# Altium schematic sheet Circuit_Schematic.SchDoc
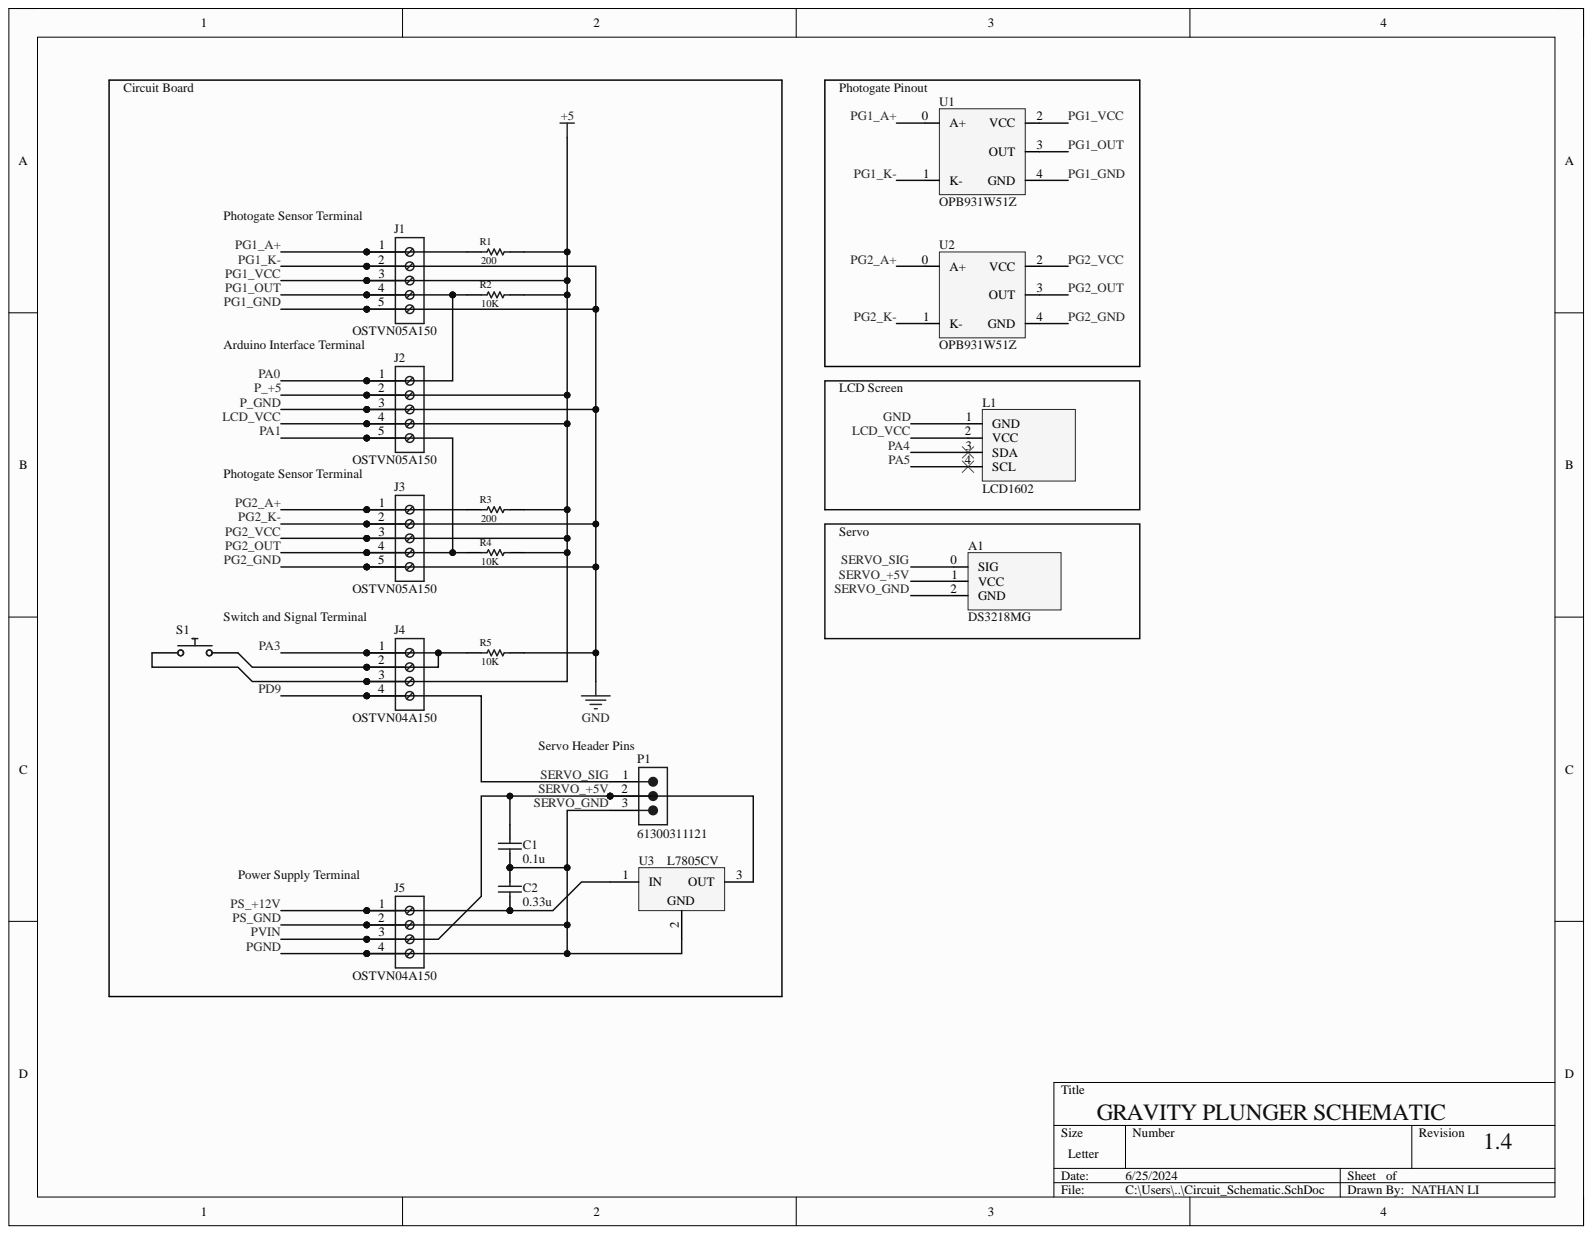
[diagram p0: Circuit_Schematic.SchDoc]

## Parts
A1  DS3218MG
C1  value 0.1u  (hidden comment: Capacitor)
C2  value 0.33u  (hidden comment: Capacitor)
J1  OSTVN05A150  [691127700005B]
J2  OSTVN05A150  [691127700005B]
J3  OSTVN05A150  [691127700005B]
J4  OSTVN04A150  [691709710304]
J5  OSTVN04A150  [691709710304]
L1  LCD1602
P1  61300311121  [61300311121]
R1  value 200  (hidden comment: Resistor)
R2  value 10K  (hidden comment: Resistor)
R3  value 200  (hidden comment: Resistor)
R4  value 10K  (hidden comment: Resistor)
R5  value 10K  (hidden comment: Resistor)
S1  Push Button Normally Opened  (value not drawn)
U1  OPB931W51Z
U2  OPB931W51Z
U3  L7805CV  [TO220]

## Nets
GND: J1.2 J1.5 J2.3 J3.2 J3.5 L1.1(GND) R5.2 U1.1(K-) U1.4(GND) U2.1(K-) U2.4(GND)
LCD_VCC: J1.3 J2.2 J2.4 J3.3 J4.3 L1.2(VCC) R1.2 R2.2 R3.2 R4.2 S1.1 U1.2(VCC) U2.2(VCC)
PA0: J1.4 J2.1 R2.1 U1.3(OUT)
PA1: J2.5 J3.4 R4.1 U2.3(OUT)
PA3: J4.1 J4.2 R5.1 S1.2
PA4: L1.3(SDA)
PA5: L1.4(SCL)
PD9: A1.0 J4.4 P1.1
PG1_A+: J1.1 R1.1 U1.0(A+)
PG2_A+: J3.1 R3.1 U2.0(A+)
PGND: A1.2 C1.2 C2.2 J5.2 J5.4 P1.3 U3.2
PS_+12V: C2.1 J5.1 U3.1
PVIN: A1.1 C1.1 J5.3 P1.2 U3.3

## Library data of the parts
A1 = DS3218MG: 270 Degree Servo; Component Kind: Standard; ComponentLink1Description: Datasheet; ComponentLink1URL: https://images-na.ssl-images-amazon.com/images/I/81Lbgu+nG6L.pdf; ComponentLink2Description: Supplier Link; ComponentLink2URL: https://www.amazon.com/ANNIMOS-Digital-Waterproof-DS3218MG-Control/dp/B076CNKQX4; Library Name: SchemLib.SchLib
C1 C2 = Capacitor: SimulationGenericComponent: True; Component Kind: Standard; Description: Capacitor; Library Name: Simulation Generic Components.IntLib; Simulation: C_Capacitor_Default
J1-J3 = CMP-1502-03273-2: NexarPartId: 27602165; Connector Type: Terminal Block; Current: 20A; Number of Contacts: 5; Number of Levels: 1; Pitch: 5.0038mm; Plating: Steel; Stripping Length: 9mm; Voltage: 300V; Wire Gauge (Max): 30AWG; Wire Gauge (Min): 12AWG; Color: Green; Component Kind: Standard; Contact Material: Brass; Contact Plating: Tin; Current Rating: 20A; Height: 19.5mm; Housing Material: Nylon; Lead Pitch: 5mm; Length: 18.6mm; Library Name: Altium Content Vault; Max Operating Temperature: 105°C; Min Operating Temperature: -40°C; Mount: Through Hole; Number of Pins: 5; Number of Positions Per Level: 5; Packaging: Box; REACH SVHC: Unknown; RoHS: Compliant; Termination: Screw; Voltage Rating: 300V; Width: 27.5mm; Wire/Cable Gauge: 30AWG
J4 J5 = CMP-1502-03337-2: NexarPartId: 34171771; Connector Type: Screw; Current: 6A; Number of Contacts: 4; Number of Levels: 1; Pitch: 5mm; Plating: Steel; Voltage: 300V; Wire Gauge (Max): 30AWG; Color: Black; Component Kind: Standard; Contact Material: Nickel; Contact Plating: Tin; Current Rating: 6A; Flammability Rating: UL94 V-0; Height: 10.2mm; Housing Material: Nylon; Lead Pitch: 5mm; Length: 20mm; Library Name: Altium Content Vault; Max Operating Temperature: 130°C; Min Operating Temperature: -40°C; Mount: Surface Mount; Number of Pins: 4; Number of Positions: 4; Number of Positions Per Level: 4; Number of Rows: 1; Packaging: Digi-Reel®; REACH SVHC: Unknown; RoHS: Compliant; Termination: Screw; Voltage Rating: 300V; Wire Gauge (Min): 30AWG; Wire/Cable Gauge: 30AWG
L1 = LCD 16x2: Liquid I2C LCD 16x2 Display; Component Kind: Standard; Library Name: SchemLib.SchLib
P1 = CMP-1502-01062-3: NexarPartId: 32855521; Connector Type: Male Pin; Contact Resistance: 20mΩ; Current Rating: 3A; Gender: Male; Number of Contacts: 3; Operating Supply Voltage: 250V; Pitch: 2.54mm; Color: Black; Component Kind: Standard; Contact Material: Copper; Contact Mating Length: 5.9944mm; Contact Plating: Gold; Height: 8.94mm; Lead Free: Lead Free; Lead Pitch: 2.54mm; Library Name: Altium Content Vault; Max Operating Temperature: 105°C; Min Operating Temperature: -40°C; Mount: Through Hole; Number of Pins: 3; Number of Positions: 3; Number of Rows: 1; Orientation: Vertical; Packaging: Bulk; REACH SVHC: Unknown; RoHS: Compliant; Termination: Solder; Voltage Rating: 250V
R1-R5 = Resistor: SimulationGenericComponent: True; TC1: 0; Component Kind: Standard; Description: Resistor; Library Name: Simulation Generic Components.IntLib; Simulation: R_Resistor_Default; TC2: 0
S1 = Push Button NO: closed: 0; Rclosed: 1m; Ropen: 1Meg; SimulationGenericComponent: True; Component Kind: Standard; Description: Push Button Normally Opened; Library Name: Simulation Generic Components.IntLib; Simulation: PUSH_BUTTON_NO
U1 U2 = OPB931W51Z: Photogate Interrupter; Component Kind: Standard; ComponentLink1Description: Datasheet; ComponentLink1URL: https://www.ttelectronics.com/TTElectronics/media/ProductFiles/Datasheet/OPB930-940-L-W.pdf; Library Name: SchemLib.SchLib; Supplier 1: Newark; Supplier Part Number 1: 73K1183
U3 = CMP-0244-00483-1: Positive Voltage Regulator, 5V, 3-Pin TO-220; Component Kind: Standard; ComponentLink1Description: Manufacturer URL; ComponentLink1URL: http://www.st.com; ComponentLink2Description: Datasheet; ComponentLink2URL: http://www.st.com/internet/com/TECHNICAL_RESOURCES/TECHNICAL_LITERATURE/DATASHEET/CD00000444.pdf; ComponentLink3Description: Package Specification; ComponentLink3URL: http://www.st.com/internet/com/TECHNICAL_RESOURCES/TECHNICAL_LITERATURE/PACKAGE_INFORMATION/CD00004649.pdf; DatasheetVersion: 16/11/2011; Library Name: Altium Content Vault; PackageDescription: TO, Thru-Hole, Vertical, Heatsink Mounted; 3 In-Line Leads; Pitch 2.54 mm; PackageReference: TO220; PackageVersion: ver3.0
